# Altium schematic sheet Sheet3.SchDoc(Sheet3)
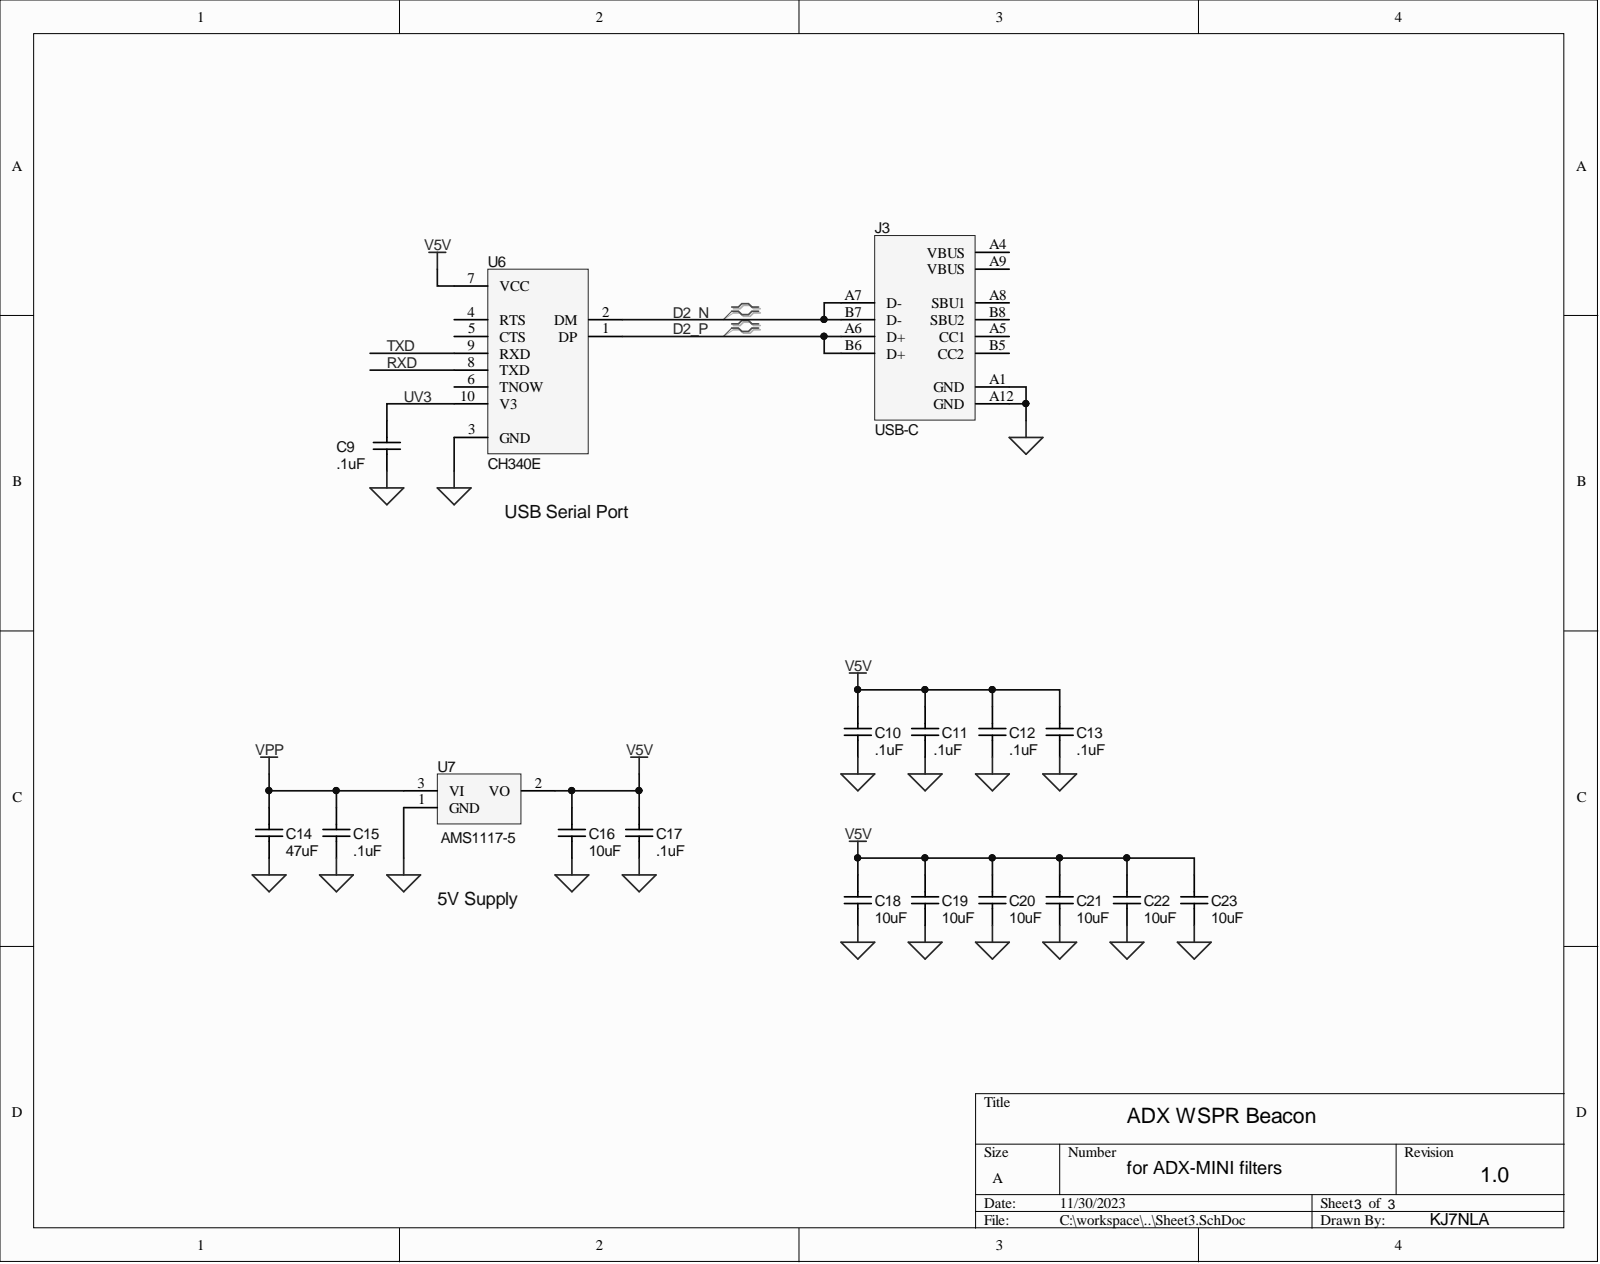
[diagram p0: Sheet3.SchDoc]

## Parts
C9  .1uF  [3-0603]
C10  .1uF  [3-0603]
C11  .1uF  [3-0603]
C12  .1uF  [3-0603]
C13  .1uF  [3-0603]
C14  47uF  [8-1206]
C15  .1uF  [3-0603]
C16  10uF  [3-0603]
C17  .1uF  [3-0603]
C18  10uF  [3-0603]
C19  10uF  [3-0603]
C20  10uF  [3-0603]
C21  10uF  [3-0603]
C22  10uF  [3-0603]
C23  10uF  [3-0603]
J3  USB-C  [USB-C]
U6  CH340E  [MSOP-10]
U7  AMS1117-5  [SOT-223]

## Nets
GND: C9.1 C10.1 C11.1 C12.1 C13.1 C14.1 C15.1 C16.1 C17.1 C18.1 C19.1 C20.1 C21.1 C22.1 C23.1 J3.A1(GND) J3.A12(GND) U6.3(GND) U7.1
V5V: C10.2 C11.2 C12.2 C13.2 C16.2 C17.2 C18.2 C19.2 C20.2 C21.2 C22.2 C23.2 U6.7(VCC) U7.2
VPP: C14.2 C15.2 U7.3
D2_N: J3.A7(D-) J3.B7(D-) U6.2(DM)
D2_P: J3.A6(D+) J3.B6(D+) U6.1(DP)
RXD: U6.8(TXD)
TXD: U6.9(RXD)
UV3: C9.2 U6.10(V3)

Not connected: J3 A4(VBUS) A5(CC1) A8(SBU1) A9(VBUS) B5(CC2) B8(SBU2); U6 4(RTS) 5(CTS) 6(TNOW)

## Library data of the parts
C9-C23 = Cap: Supplier Part Number 1: NA; Supplier 1: Digi-Key; Component Kind: Standard; Library Name: usdx.SchLib
  C9-C13 C15 C17: Value: .1uF; JLCC Part Number: C113803
  C14: Value: 47uF; JLCC Part Number: C403725
  C16 C18-C23: Value: 10uF; JLCC Part Number: C344022
U7 = AMS1117: Supplier Part Number 1: NA; Supplier 1: Digi-Key; JLCC Part Number: C6187; Component Kind: Standard; Library Name: usdx.SchLib
J3 = USB-C: Supplier Part Number 1: NA; Supplier 1: Digi-Key; JLCC Part Number: C2765186; Component Kind: Standard; Library Name: usdx.SchLib
U6 = CH340E: Supplier Part Number 1: NA; Supplier 1: Digi-Key; JLCC Part Number: C99652; Component Kind: Standard; Library Name: usdx.SchLib
